# Altium schematic sheet [03] - POWER HIGH STAGE.SchDoc("[03] - POWER HIGH STAGE") (continued)

## Library data of the parts
C1 = Cap 0805 10nF/50V: 0805 10 nF 50 V ±5 % Tolerance X7R SMT Multilayer Ceramic Capacitor; Manufacturer Part Number: CC0805JRX7R9BB103; Manufacturer: Yageo; Voltage Rating (DC): 50V; Tolerance: 5%; Insulation Resistance: 10GR; Dissipation Factor: 2.5%; Capacitance: 10nF; Width: 0.049inch; Voltage Rating: 50V; Thickness: 0.071inch; Termination: SMD/SMT; Supplier Part Number 1: 311-1857-1-ND; Supplier 1: Digi-Key; Stock 1: 125618; Series: CC; RoHS Compliant: Yes; RoHS: Yes; Resistor Type: Ceramic; Radiation Hardening: No; Pricing 1: 1 = 0.14000, 10 = 0.09900, 50 = 0.05620, 100 = 0.04650, 500 = 0.03262, 1000 = 0.02700 (USD); Packaging: Tape and Reel; Package Quantity: 4000; Number of Pins: 2; Mount: Surface Mount; Min Operating Temperature: -55°C; Max Operating Temperature: 125°C; Length: 2mm; Lead Free: Lead Free; Height: 0.6mm; Halogen Free: Halogen Free; Dielectric: X7R; Depth: 1.25mm; Construction: Flat; ComponentLink4URL: https://datasheet.ciiva.com/13385/upy-gphc-x7r-6-3v-to-50v-8-13385902.pdf?src-supplier=Mouser; ComponentLink4Description: https://datasheet.ciiva.com/13385/upy-gphc-x7r-6-3v-to-50v-8-13385902.pdf?src-supplier=Mouser; ComponentLink3URL: https://datasheet.ciiva.com/11583/upy-gphc-x7r-6-3v-to-50v-8-11583532.pdf?src-supplier=Avnet; ComponentLink3Description: https://datasheet.ciiva.com/11583/upy-gphc-x7r-6-3v-to-50v-8-11583532.pdf?src-supplier=Avnet; ComponentLink2URL: https://datasheet.ciiva.com/17434/upy-gphc-x7r-6-3v-to-50v-8-17434678.pdf?src-supplier=Avnet+Europe; ComponentLink2Description: https://datasheet.ciiva.com/17434/upy-gphc-x7r-6-3v-to-50v-8-17434678.pdf?src-supplier=Avnet+Europe; ComponentLink1URL: https://datasheet.ciiva.com/26862/getdatasheetpartid-251801-26862952.pdf?src-supplier=Verical; ComponentLink1Description: https://datasheet.ciiva.com/26862/getdatasheetpartid-251801-26862952.pdf?src-supplier=Verical; Component Kind: Standard; Case Code (Metric): 2012; Case Code (Imperial): 0805; Case/Package: 0805; Library Name: Hieu_Lib.IntLib
C2 = Cap 0805 47nF/100V: Cap Ceramic 0.047uF 100V X7R 10% Pad SMD 0805 125C Automotive T/R; Manufacturer Part Number: CC0805KKX7R0BB473; Manufacturer: Yageo; Capacitance: 47nF; Width: 0.049inch; Weight: 0.000194oz; Voltage Rating (DC): 100V; Voltage Rating: 100V; Tolerance: 10%; Thickness: 0.057inch; Termination: SMD/SMT; Supplier Part Number 1: 311-1876-1-ND; Supplier 1: Digi-Key; Stock 1: 8993; Series: CC; RoHS Compliant: Yes; RoHS: Yes; Resistor Type: Ceramic; Radiation Hardening: No; Pricing 1: 1 = 0.19000, 10 = 0.13200, 50 = 0.07900, 100 = 0.06670, 500 = 0.04562, 1000 = 0.04036 (USD); Packaging: Tape and Reel; Mount: Surface Mount; Min Operating Temperature: -55°C; Max Operating Temperature: 125°C; Length: 2mm; Lead Free: Lead Free; Height: 1.25mm; Dielectric: X7R; Depth: 1.25mm; Construction: Flat; ComponentLink2URL: https://datasheet.ciiva.com/17434/upy-np0x7r-mv-100-to-630v-9-17434765.pdf?src-supplier=Avnet+Europe; ComponentLink2Description: https://datasheet.ciiva.com/17434/upy-np0x7r-mv-100-to-630v-9-17434765.pdf?src-supplier=Avnet+Europe; ComponentLink1URL: https://datasheet.ciiva.com/11583/upy-np0x7r-mv-100-to-630v-9-11583592.pdf?src-supplier=Avnet; ComponentLink1Description: https://datasheet.ciiva.com/11583/upy-np0x7r-mv-100-to-630v-9-11583592.pdf?src-supplier=Avnet; Component Kind: Standard; Case Code (Metric): 2012; Case Code (Imperial): 0805; Case/Package: 0805; Library Name: Hieu_Lib.IntLib
C3 C4 = Cap Tant 2917 22uF/35V: 22uF Solid MnO2 Tantalum Electrolytic Capacitor; 35 V dc +/-10%; T491 Series; Manufacturer Part Number: T491X226K035AT; Manufacturer: KEMET; Voltage Rating (DC): 35V; Tolerance: 10%; Polarity: Polar; Life (Hours): 2000Hour; Leakage Current: 7.7uA; ESR (Equivalent Series Resistance): 700mR; Dissipation Factor: 6%; Width: 4.3mm; Voltage Rating: 35V; Voltage: 35V; Termination: SMD/SMT; Supplier Part Number 1: 80-T491X226K035; Supplier 1: Mouser; Stock 1: 3885; Series: T491; RoHS Compliant: Yes; RoHS: Yes; REACH SVHC: No SVHC; Pricing 1: 1 = 2.02000, 10 = 1.39000, 50 = 1.39000, 100 = 1.20000, 1000 = 0.90300, 10000 = 0.90300 (USD); Packaging: Tape and Reel; Package Quantity: 1; Number of Pins: 2; Mount: Surface Mount,PCB; Min Operating Temperature: -55°C; Max Operating Temperature: 125°C; Length: 7.3mm; Lead Free: Lead Free; Height - Seated (Max): 0.169inch; Height: 4mm; Features: General Purpose; Dielectric Material: Tantalum; Depth: 4.3mm; Construction: Flat; ComponentLink8URL: https://datasheet.ciiva.com/14603/70095774-14603077.pdf?src-supplier=Allied+Electronics; ComponentLink8Description: https://datasheet.ciiva.com/14603/70095774-14603077.pdf?src-supplier=Allied+Electronics; ComponentLink7URL: https://datasheet.ciiva.com/12882/kem-t2005-t491-12882597.pdf?src-supplier=Mouser; ComponentLink7Description: https://datasheet.ciiva.com/12882/kem-t2005-t491-12882597.pdf?src-supplier=Mouser; ComponentLink6URL: https://datasheet.ciiva.com/260/kem-t2005-t491-260899.pdf?src-supplier=Digi-Key; ComponentLink6Description: https://datasheet.ciiva.com/260/kem-t2005-t491-260899.pdf?src-supplier=Digi-Key; ComponentLink5URL: https://datasheet.ciiva.com/7758/1446014-7758999.pdf?src-supplier=Element14; ComponentLink5Description: https://datasheet.ciiva.com/7758/1446014-7758999.pdf?src-supplier=Element14; ComponentLink4URL: https://datasheet.ciiva.com/7758/1446014-7758998.pdf?src-supplier=Element14; ComponentLink4Description: https://datasheet.ciiva.com/7758/1446014-7758998.pdf?src-supplier=Element14; ComponentLink3URL: https://datasheet.ciiva.com/260/kem-t2005-t491-260901.pdf?src-supplier=Digi-Key; ComponentLink3Description: https://datasheet.ciiva.com/260/kem-t2005-t491-260901.pdf?src-supplier=Digi-Key; ComponentLink2URL: https://datasheet.ciiva.com/260/kem-t2005-t491-260900.pdf?src-supplier=Digi-Key; ComponentLink2Description: https://datasheet.ciiva.com/260/kem-t2005-t491-260900.pdf?src-supplier=Digi-Key; ComponentLink1URL: https://datasheet.ciiva.com/11793/0900766b80718482-11793603.pdf?src-supplier=RSComponents; ComponentLink1Description: https://datasheet.ciiva.com/11793/0900766b80718482-11793603.pdf?src-supplier=RSComponents; Component Kind: Standard; Case Code (Metric): 7343; Case Code (Imperial): 2917; Case/Package: 2917; Capacitance: 22uF; Library Name: Hieu_Lib.IntLib
C5 C10 = Cap 0805 1uF/50V: 0805 1 uF 50 V ±10 % Tolerance X7R SMT Multilayer Ceramic Capacitor; Manufacturer Part Number: CC0805KKX7R9BB105; Manufacturer: Yageo; Voltage Rating (DC): 50V; Tolerance: 10%; Capacitance: 1uF; Width: 0.049inch; Weight: 0.000194oz; Voltage Rating: 50V; Thickness: 0.057inch; Termination: SMD/SMT; Supplier Part Number 1: 311-1886-1-ND; Supplier 1: Digi-Key; Stock 1: 174238; Series: CC; RoHS Compliant: Yes; RoHS: Yes; Resistor Type: Ceramic; Radiation Hardening: No; Pricing 1: 1 = 0.26000, 10 = 0.17900, 50 = 0.11920, 100 = 0.10130, 500 = 0.07152, 1000 = 0.06257 (USD); Packaging: Tape and Reel; Mount: Surface Mount; Min Operating Temperature: -55°C; Max Operating Temperature: 125°C; Length: 2mm; Lead Free: Lead Free; Height: 1.25mm; Halogen Free: Halogen Free; Dielectric: X7R; Depth: 1.25mm; Construction: Flat; ComponentLink1URL: https://datasheet.ciiva.com/13209/upy-gphc-x7r-6-3v-to-50v-8-12304-13209375.pdf?src-supplier=Mouser; ComponentLink1Description: https://datasheet.ciiva.com/13209/upy-gphc-x7r-6-3v-to-50v-8-12304-13209375.pdf?src-supplier=Mouser; Component Kind: Standard; Case Code (Metric): 2012; Case Code (Imperial): 0805; Case/Package: 0805; Library Name: Hieu_Lib.IntLib
C6 C9 C13 = Cap 0805 100nF/50V: Ceramic capacitor SMD-0805 100nF /50V X7R# K +-10%, Samsung, RoHS; Manufacturer Part Number: CL21B104KBCNNNC; Voltage Rating (DC): 50V; Tolerance: 10%; Dielectric: X7R; Width: 0.049inch; Voltage Rating: 50V; Voltage: 50V; Thickness: 0.037inch; Supplier Part Number 1: C1711; Supplier 1: LCSC; Stock 1: 447650; Series: CL; RoHS Compliant: Yes; RoHS: Yes; Radiation Hardening: No; Pricing 1: 50 = 0.04090, 500 = 0.03130, 1500 = 0.02890, 5000 = 0.02580, 25000 = 0.02430, 50000 = 0.02410, 50 = 0.03950, 500 = 0.03020, 1500 = 0.02790, 5000 = 0.02490, 25000 = 0.02350, 50000 = 0.02330, 50 = 0.20680, 500 = 0.15820, 1500 = 0.14600, 5000 = 0.13020, 25000 = 0.12290, 50000 = 0.12170, 50 = 0.19640, 500 = 0.15020, 1500 = 0.13860, 5000 = 0.12360, 25000 = 0.11670, 50000 = 0.11550, 50 = 0.02640, 500 = 0.02020, 1500 = 0.01860, 5000 = 0.01660, 25000 = 0.01570, 50000 = 0.01550, 50 = 0.02300, 500 = 0.01760, 1500 = 0.01620, 5000 = 0.01440, 25000 = 0.01360, 50000 = 0.01350, 50 = 0.24740, 500 = 0.18920, 1500 = 0.17470, 5000 = 0.15570, 25000 = 0.14700, 50000 = 0.14560, 50 = 3.45220, 500 = 2.64000, 1500 = 2.43700, 5000 = 2.17300, 25000 = 2.05110, 50000 = 2.03080, 50 = 0.13070, 500 = 0.09990, 1500 = 0.09220, 5000 = 0.08230, 25000 = 0.07760, 50000 = 0.07690, 50 = 2.39050, 500 = 1.82810, 1500 = 1.68750, 5000 = 1.50470, 25000 = 1.42030, 50000 = 1.40620, 50 = 0.26740, 500 = 0.20450, 1500 = 0.18870, 5000 = 0.16830, 25000 = 0.15890, 50000 = 0.15730, 50 = 0.04230, 500 = 0.03230, 1500 = 0.02990, 5000 = 0.02660, 25000 = 0.02510, 50000 = 0.02490, 50 = 0.03188, 500 = 0.02438, 1500 = 0.02251, 5000 = 0.02007, 25000 = 0.01894, 50000 = 0.01875 (AUD); Packaging: Tape and Reel; Mount: Surface Mount; Min Operating Temperature: -55°C; Max Operating Temperature: 125°C; Material: Ceramic; Manufacturer: Samsung; Length: 2mm; Lead Free: Lead Free; Height: 0.85mm; Depth: 1.25mm; Contact Plating: Tin; Construction: Flat; ComponentLink2URL: https://datasheet.ciiva.com/29199/454152-da-01-en-vs-kondensator-0805-29199814.pdf?src-supplier=Conrad; ComponentLink2Description: https://datasheet.ciiva.com/29199/454152-da-01-en-vs-kondensator-0805-29199814.pdf?src-supplier=Conrad; ComponentLink1URL: https://datasheet.ciiva.com/25710/cl21b104kbcnnnc-25710182.pdf?src-supplier=Digi-Key; ComponentLink1Description: https://datasheet.ciiva.com/25710/cl21b104kbcnnnc-25710182.pdf?src-supplier=Digi-Key; Component Kind: Standard; Case Code (Metric): 2012; Case Code (Imperial): 0805; Case/Package: 0805; Capacitance: 100nF; Library Name: Hieu_Lib.IntLib
C7 C8 = Cap Tant 2917 47uF/20V: Capacitor Tantalum Polymer 47uF 20% 20V 2-Pin Surface Mount T/R; Manufacturer Part Number: TCJY476M020R0070E; Manufacturer: Kyocera AVX; Supplier Part Number 1: 478-13360-1-ND; Supplier 1: Digi-Key; Stock 1: 4815; RoHS: Yes; Pricing 1: 1 = 1.37000, 10 = 1.08300, 50 = 0.93880, 100 = 0.81250, 500 = 0.61388 (USD); Component Kind: Standard; Library Name: Hieu_Lib.IntLib
C11 = Cap 0805 1nF/200V: Surface Mount Ceramic Multilayer Capacitor 0.001uF 10% X7R 200V 0805 Paper T/R; Manufacturer Part Number: CC0805KRX7RABB102; Manufacturer: Yageo; Capacitance: 1nF; Width: 0.049inch; Voltage Rating (DC): 200V; Voltage Rating: 200V; Tolerance: 10%; Thickness: 0.037inch; Termination: SMD/SMT; Supplier Part Number 1: 311-1469-1-ND; Supplier 1: Digi-Key; Stock 1: 74929; Series: CC; RoHS Compliant: Yes; RoHS: Yes; Resistor Type: Ceramic; REACH SVHC: No SVHC; Radiation Hardening: No; Pricing 1: 1 = 0.12000, 10 = 0.08400, 50 = 0.04780, 100 = 0.03970, 500 = 0.02782, 1000 = 0.02303 (USD); Packaging: Tape and Reel; Package Quantity: 4000; Number of Pins: 2; Mount: Surface Mount; Min Operating Temperature: -55°C; Max Operating Temperature: 125°C; Length: 2mm; Lead Free: Lead Free; Height: 0.85mm; Dielectric: X7R; Depth: 1.25mm; ComponentLink8URL: https://datasheet.ciiva.com/17436/upy-np0x7r-mv-100-to-630v-9-17436473.pdf?src-supplier=Avnet+Europe; ComponentLink8Description: https://datasheet.ciiva.com/17436/upy-np0x7r-mv-100-to-630v-9-17436473.pdf?src-supplier=Avnet+Europe; ComponentLink7URL: https://datasheet.ciiva.com/7978/1447671-7978217.pdf?src-supplier=Element14; ComponentLink7Description: https://datasheet.ciiva.com/7978/1447671-7978217.pdf?src-supplier=Element14; ComponentLink6URL: https://datasheet.ciiva.com/11465/upy-np0x7r-mv-100-to-630v-9-11465767.pdf?src-supplier=Avnet; ComponentLink6Description: https://datasheet.ciiva.com/11465/upy-np0x7r-mv-100-to-630v-9-11465767.pdf?src-supplier=Avnet; ComponentLink5URL: https://datasheet.ciiva.com/177/mlccorderingcode-177538.pdf?src-supplier=Digi-Key; ComponentLink5Description: https://datasheet.ciiva.com/177/mlccorderingcode-177538.pdf?src-supplier=Digi-Key; ComponentLink4URL: https://datasheet.ciiva.com/13210/yageo-486313-494411-13210767.pdf?src-supplier=Mouser; ComponentLink4Description: https://datasheet.ciiva.com/13210/yageo-486313-494411-13210767.pdf?src-supplier=Mouser; ComponentLink3URL: https://datasheet.ciiva.com/177/mlccorderingcode-177540.pdf?src-supplier=Digi-Key; ComponentLink3Description: https://datasheet.ciiva.com/177/mlccorderingcode-177540.pdf?src-supplier=Digi-Key; ComponentLink2URL: https://datasheet.ciiva.com/177/mlccorderingcode-177539.pdf?src-supplier=Digi-Key; ComponentLink2Description: https://datasheet.ciiva.com/177/mlccorderingcode-177539.pdf?src-supplier=Digi-Key; ComponentLink1URL: https://datasheet.ciiva.com/26862/getdatasheetpartid-403717-26862996.pdf?src-supplier=Verical; ComponentLink1Description: https://datasheet.ciiva.com/26862/getdatasheetpartid-403717-26862996.pdf?src-supplier=Verical; Component Kind: Standard; Case Code (Metric): 2012; Case Code (Imperial): 0805; Case/Package: 0805; Library Name: Hieu_Lib.IntLib
C12 = Cap Aluminum 68uF/100V: Cap Aluminum Lytic 68uF 100V 20% (10 X 16mm) Radial 5mm 930mA 8000h 105C Bulk; Manufacturer Part Number: EEUFS2A680; Manufacturer: Panasonic; Supplier Part Number 1: 667-EEU-FS2A680; Supplier 1: Mouser; Stock 1: 1647; RoHS Compliant: Yes; RoHS: Yes; Pricing 1: 1 = 0.91000, 10 = 0.67400, 50 = 0.67400, 100 = 0.46300, 600 = 0.36600, 1000 = 0.30800, 10000 = 0.26900 (USD); Component Kind: Standard; Library Name: Hieu_Lib.IntLib
C14 C19 = Cap Disc 1nF/2kV: ±10% 1nF 3mm Y5P 8mm 5mm 2kV Radial Leaded,P=5mm Ceramic Disc Capacitors ROHS; Manufacturer Part Number: R08B1B102KQ0T0S0N0; Manufacturer: STE(Songtian Elec); Supplier Part Number 1: C366824; Supplier 1: LCSC; Component Kind: Standard; Library Name: Hieu_Lib.IntLib
C15 = Cap Aluminum 680uF/50V 1840mA: Cap Aluminum Lytic 680uF 50V 20%( 16 X 20mm) Radial 7.5mm 1840mA 8000h 105C Automotive Bulk; Manufacturer Part Number: ELXZ500ELL681ML20S; Manufacturer: United Chemi-Con; Ripple Current: 1.84A; Life (Hours): 8000Hour; Leakage Current: 3uA; Lead Diameter: 0.8mm; Impedance: 48mR; ESR (Equivalent Series Resistance): 96mR; Voltage Rating (DC): 50V; Voltage Rating: 50V; Voltage: 50V; Tolerance: 20%; Termination: Radial; Supplier Part Number 1: 661-ELXZ500ELL681ML2; Supplier 1: Mouser; Stock 1: 496; Series: LXZ; RoHS Compliant: Yes; RoHS: Yes; Pricing 1: 1 = 2.03000, 10 = 1.65000, 50 = 1.65000, 100 = 1.26000, 1000 = 0.83800, 10000 = 0.76300 (USD); Packaging: Bulk; Package Quantity: 500; Number of Pins: 20; Mount: Through Hole; Min Operating Temperature: -55°C; Max Operating Temperature: 105°C; Length: 20mm; Lead Pitch: 0.295inch; Lead Free: Lead Free; Height - Seated (Max): 0.787inch; Height: 20mm; Diameter: 16mm; Construction: RADIAL; ComponentLink1URL: https://datasheet.ciiva.com/25613/0900766b81084d89-25613840.pdf?src-supplier=RSComponents; ComponentLink1Description: https://datasheet.ciiva.com/25613/0900766b81084d89-25613840.pdf?src-supplier=RSComponents; Component Kind: Standard; Case/Package: Radial; Capacitance: 680uF; Library Name: Hieu_Lib.IntLib
C16 = Cap Aluminum 330uF/50V: Cap Aluminum 330uF 50V 20% (12.5 X 20mm) Radial 5mm 0.383 Ohm 1820mA 7000 hr 125°C Bulk; Manufacturer Part Number: B41896C6337M000; Manufacturer: TDK EPCOS; Ripple Current: 1.82A; Life (Hours): 7000Hour; Lead Diameter: 0.6mm; ESR (Equivalent Series Resistance): 383mR; Tolerance: 20%; Supplier Part Number 1: 871-B41896C6337M000; Supplier 1: Mouser; Stock 1: 7307; RoHS Compliant: Yes; RoHS: Yes; Pricing 1: 1 = 1.68000, 10 = 1.16000, 50 = 1.16000, 100 = 1.00000, 1000 = 0.75500, 10000 = 0.59900 (USD); Packaging: Bulk; Min Operating Temperature: -55°C; Max Operating Temperature: 125°C; Lead Pitch: 5mm; ComponentLink2URL: https://www.tdk-electronics.tdk.com/inf/20/30/db/aec/B41896.pdf?src-supplier=Digi-Key; ComponentLink2Description: https://www.tdk-electronics.tdk.com/inf/20/30/db/aec/B41896.pdf?src-supplier=Digi-Key; ComponentLink1URL: http://components.arrow.com/part/dataview/0323_01840345?src-supplier=Arrow+Europe; ComponentLink1Description: http://components.arrow.com/part/dataview/0323_01840345?src-supplier=Arrow+Europe; Component Kind: Standard; Case/Package: Radial; Capacitance: 330uF; Library Name: Hieu_Lib.IntLib
C17 = Cap Aluminum 220uF/25V: Electrolytic Capacitor, Wcap-Atll Series, 220 F, 20%, 25 V, 8 Mm, Radial Leaded Rohs Compliant: Yes; Manufacturer Part Number: 860160474017; Manufacturer: Wurth Electronics; Voltage Rating (DC): 25V; Material: Aluminium; Life (Hours): 7000Hour; Capacitance: 220uF; Voltage Rating: 25V; Tolerance: 20%; Supplier Part Number 1: 732-9517-1-ND; Supplier 1: Digi-Key; Stock 1: 110; RoHS Compliant: Yes; RoHS: Yes; REACH SVHC: Unknown; Pricing 1: 1 = 0.33000, 50 = 0.27800, 100 = 0.24200, 250 = 0.21500 (USD); Min Operating Temperature: -55°C; Max Operating Temperature: 105°C; Lead Pitch: 3.5mm; Height: 11.5mm; Diameter: 8mm; ComponentLink4URL: http://www.farnell.com/datasheets/1958410.pdf?src-supplier=Element14; ComponentLink4Description: http://www.farnell.com/datasheets/1958410.pdf?src-supplier=Element14; ComponentLink3URL: https://katalog.we-online.de/pbs/datasheet/860160474017.pdf?src-supplier=Digi-Key; ComponentLink3Description: https://katalog.we-online.de/pbs/datasheet/860160474017.pdf?src-supplier=Digi-Key; ComponentLink2URL: http://www.produktinfo.conrad.com/datenblaetter/1200000-1299999/001281591-da-01-en-WCAP_ATLL_ALU_ELEC_CAPS_860160474017.pdf?src-supplier=Conrad; ComponentLink2Description: http://www.produktinfo.conrad.com/datenblaetter/1200000-1299999/001281591-da-01-en-WCAP_ATLL_ALU_ELEC_CAPS_860160474017.pdf?src-supplier=Conrad; ComponentLink1URL: http://www.farnell.com/datasheets/1958410.pdf?src-supplier=Farnell; ComponentLink1Description: http://www.farnell.com/datasheets/1958410.pdf?src-supplier=Farnell; Component Kind: Standard; Case/Package: Radial; Library Name: Hieu_Lib.IntLib
C18 = Cap 1206 4.7uF/50V: Cap Ceramic 4.7uF 50V X7R 10% Pad SMD 1206 125C T/R; Manufacturer Part Number: CC1206KKX7R9BB475; Manufacturer: Yageo; Width: 0.063inch; Voltage Rating: 50V; Tolerance: 10%; Thickness: 0.071inch; Supplier Part Number 1: 603-CC206KKX7R9BB475; Supplier 1: Mouser; Stock 1: 11897; RoHS Compliant: Yes; RoHS: Yes; Pricing 1: 1 = 0.54000, 10 = 0.30800, 50 = 0.30800, 100 = 0.22500, 500 = 0.16900, 1000 = 0.14400, 2000 = 0.13500, 10000 = 0.13500, 24000 = 0.11800 (USD); Packaging: Tape and Reel; Mount: Surface Mount; Min Operating Temperature: -55°C; Max Operating Temperature: 125°C; Length: 0.126inch; Lead Free: Lead Free; Halogen Free: Halogen Free; ComponentLink3URL: http://www.yageo.com/documents/recent/UPY-GPHC_X7R_6.3V-to-50V_18.pdf?src-supplier=Digi-Key; ComponentLink3Description: http://www.yageo.com/documents/recent/UPY-GPHC_X7R_6.3V-to-50V_18.pdf?src-supplier=Digi-Key; ComponentLink2URL: http://components.arrow.com/part/dataview/0323_07044541?src-supplier=Arrow+Europe; ComponentLink2Description: http://components.arrow.com/part/dataview/0323_07044541?src-supplier=Arrow+Europe; ComponentLink1URL: http://www.mouser.com/ds/2/447/Yageo_UPY-GP_NP0_16V-to-50V_9-358835.pdf?src-supplier=Mouser; ComponentLink1Description: http://www.mouser.com/ds/2/447/Yageo_UPY-GP_NP0_16V-to-50V_9-358835.pdf?src-supplier=Mouser; Component Kind: Standard; Case Code (Metric): 3216; Case Code (Imperial): 1206; Case/Package: 1206; Capacitance: 4.7uF; Library Name: Hieu_Lib.IntLib
D1 D2 = Zenner 12V 500mW: 100nA 12V 500mW LL-34 Zener Diodes ROHS; Manufacturer Part Number: ZMM12; Manufacturer: Slkor; Supplier Part Number 1: C707203; Supplier 1: LCSC; Stock 1: 37550; RoHS: No; Pricing 1: 50 = 0.11440, 500 = 0.08750, 1500 = 0.08250, 5000 = 0.07760, 25000 = 0.07540, 50000 = 0.07430, 50 = 0.11050, 500 = 0.08450, 1500 = 0.07970, 5000 = 0.07490, 25000 = 0.07280, 50000 = 0.07180, 50 = 0.57820, 500 = 0.44200, 1500 = 0.41700, 5000 = 0.39200, 25000 = 0.38090, 50000 = 0.37540, 50 = 0.54900, 500 = 0.41970, 1500 = 0.39590, 5000 = 0.37220, 25000 = 0.36160, 50000 = 0.35640, 50 = 0.07380, 500 = 0.05640, 1500 = 0.05330, 5000 = 0.05010, 25000 = 0.04860, 50000 = 0.04790, 50 = 0.06420, 500 = 0.04910, 1500 = 0.04630, 5000 = 0.04350, 25000 = 0.04230, 50000 = 0.04170, 50 = 0.69180, 500 = 0.52890, 1500 = 0.49900, 5000 = 0.46900, 25000 = 0.45570, 50000 = 0.44920, 50 = 9.65190, 500 = 7.37890, 1500 = 6.96130, 5000 = 6.54390, 25000 = 6.35830, 50000 = 6.26660, 50 = 0.36540, 500 = 0.27930, 1500 = 0.26350, 5000 = 0.24770, 25000 = 0.24070, 50000 = 0.23720, 50 = 6.68350, 500 = 5.10950, 1500 = 4.82040, 5000 = 4.53130, 25000 = 4.40280, 50000 = 4.33930, 50 = 0.74760, 500 = 0.57150, 1500 = 0.53920, 5000 = 0.50680, 25000 = 0.49250, 50000 = 0.48540, 50 = 0.11820, 500 = 0.09040, 1500 = 0.08530, 5000 = 0.08020, 25000 = 0.07790, 50000 = 0.07680, 50 = 0.08913, 500 = 0.06814, 1500 = 0.06429, 5000 = 0.06043, 25000 = 0.05872, 50000 = 0.05787 (AUD); Component Kind: Standard; Library Name: Hieu_Lib.SchLib
D3 = SS34: SS Series 500 mV 3 A 40 V Max Reverse Voltage Schottky Rectifier - DO-214AB; Manufacturer: ON Semiconductor / Fairchild; Voltage Rating (DC): 40V; Polarity: Standard; Peak Non-Repetitive Surge Current: 100A; Output Current: 3A; Number of Terminals/Pins: 2; Number of Elements: 1; Max Reverse Leakage Current: 500uA; Max Power Dissipation: 2.27W; Forward Voltage: 500mV; Element Configuration: Single; Width: 6.25mm; Voltage: 40V; Supplier Part Number 1: SS34; Supplier 1: Avnet; Stock 1: 325851; RoHS Compliant: Yes; RoHS: Yes; REACH SVHC: No SVHC; Radiation Hardening: No; Pricing 1: 1447 = 0.28183, 1500 = 0.27942, 2900 = 0.27751, 7300 = 0.27638, 15000 = 0.27554, 73000 = 0.27470, 150000 = 0.27386, 3000 = 0.27890, 6000 = 0.27650, 12000 = 0.27462, 18000 = 0.27638, 24000 = 0.27554, 30000 = 0.27470, 300000 = 0.27386 (USD); Packaging: Tape and Reel; Package Quantity: 1; Number of Pins: 2; Mount: Surface Mount; Min Operating Temperature: -55°C; Max Surge Current: 100A; Max Reverse Voltage (DC): 40V; Max Reverse Current: 500uA; Max Repetitive Reverse Voltage (Vrrm): 40V; Max Operating Temperature: 150°C; Max Forward Surge Current (Ifsm): 100A; Manufacturer Part Number: SS34; Length: 7.15mm; Lead Free: Lead Free; Height: 2.75mm; Forward Current: 3A; Current Rating: 3A; Current: 3A; Contact Plating: Tin,Matte; Component Kind: Standard; Case/Package: SMC; Average Rectified Current: 3A; Library Name: Hieu_Lib.IntLib
D4 = Zenner 5.1V 500mW: 100nA@5.1V 500mW LL-34 Zener Diodes ROHS; Manufacturer Part Number: ZMM5V1; Manufacturer: Slkor; Supplier Part Number 1: C707194; Supplier 1: LCSC; Stock 1: 89450; RoHS: No; Pricing 1: 50 = 0.11440, 500 = 0.08750, 1500 = 0.08250, 5000 = 0.07760, 25000 = 0.07540, 50000 = 0.07430, 50 = 0.11050, 500 = 0.08450, 1500 = 0.07970, 5000 = 0.07490, 25000 = 0.07280, 50000 = 0.07180, 50 = 0.57820, 500 = 0.44200, 1500 = 0.41700, 5000 = 0.39200, 25000 = 0.38090, 50000 = 0.37540, 50 = 0.54900, 500 = 0.41970, 1500 = 0.39590, 5000 = 0.37220, 25000 = 0.36160, 50000 = 0.35640, 50 = 0.07380, 500 = 0.05640, 1500 = 0.05330, 5000 = 0.05010, 25000 = 0.04860, 50000 = 0.04790, 50 = 0.06420, 500 = 0.04910, 1500 = 0.04630, 5000 = 0.04350, 25000 = 0.04230, 50000 = 0.04170, 50 = 0.69180, 500 = 0.52890, 1500 = 0.49900, 5000 = 0.46900, 25000 = 0.45570, 50000 = 0.44920, 50 = 9.65190, 500 = 7.37890, 1500 = 6.96130, 5000 = 6.54390, 25000 = 6.35830, 50000 = 6.26660, 50 = 0.36540, 500 = 0.27930, 1500 = 0.26350, 5000 = 0.24770, 25000 = 0.24070, 50000 = 0.23720, 50 = 6.68350, 500 = 5.10950, 1500 = 4.82040, 5000 = 4.53130, 25000 = 4.40280, 50000 = 4.33930, 50 = 0.74760, 500 = 0.57150, 1500 = 0.53920, 5000 = 0.50680, 25000 = 0.49250, 50000 = 0.48540, 50 = 0.11820, 500 = 0.09040, 1500 = 0.08530, 5000 = 0.08020, 25000 = 0.07790, 50000 = 0.07680, 50 = 0.08913, 500 = 0.06814, 1500 = 0.06429, 5000 = 0.06043, 25000 = 0.05872, 50000 = 0.05787 (AUD); Component Kind: Standard; Library Name: Hieu_Lib.IntLib
D5 D6 = TVS SMCJ26CA: Diode TVS Single Bi-Dir 26V 1.5KW 2-Pin DO-214AB; Manufacturer: Bourns; Test Current: 1mA; Reverse Breakdown Voltage: 28.9V; Power Line Protection: No; Polarity: Bidirectional; Peak Pulse Current: 35.6A; Operating Supply Voltage: 3.5V; Number of Elements: 1; Max Surge Current: 200A; Max Reverse Leakage Current: 5uA; Max Power Dissipation: 5W; Max Breakdown Voltage: 32.2V; Diode Type: ZENER; Clamping Voltage: 42.1V; Termination: SMD/SMT; Supplier Part Number 1: SMCJ26CABCT-ND; Supplier 1: Digi-Key; Stock 1: 0; Series: SMCJ; RoHS Compliant: Yes; RoHS: Yes; Reverse Standoff Voltage: 26V; Resistor Type: Zener; REACH SVHC: No SVHC; Peak Pulse Power: 1.5kW; Packaging: Tape and Reel; Package Quantity: 1; Number of Pins: 2; Number of Channels: 1; Number of Bidirectional Channels: 1; Mount: Surface Mount; Min Operating Temperature: -55°C; Min Breakdown Voltage: 28.9V; Max Operating Temperature: 150°C; Leakage Current: 5uA; Element Configuration: Single; Direction: Bidirectional; ComponentLink6URL: https://datasheet.ciiva.com/336/smcj-336301.pdf?src-supplier=Digi-Key; ComponentLink6Description: https://datasheet.ciiva.com/336/smcj-336301.pdf?src-supplier=Digi-Key; ComponentLink5URL: https://datasheet.ciiva.com/336/smcj-336299.pdf?src-supplier=Digi-Key; ComponentLink5Description: https://datasheet.ciiva.com/336/smcj-336299.pdf?src-supplier=Digi-Key; ComponentLink4URL: https://datasheet.ciiva.com/8031/1695389-8031190.pdf?src-supplier=Element14; ComponentLink4Description: https://datasheet.ciiva.com/8031/1695389-8031190.pdf?src-supplier=Element14; ComponentLink3URL: https://datasheet.ciiva.com/16237/smcj-16237548.pdf?src-supplier=Onlinecomponents.com; ComponentLink3Description: https://datasheet.ciiva.com/16237/smcj-16237548.pdf?src-supplier=Onlinecomponents.com; ComponentLink2URL: https://datasheet.ciiva.com/336/smcj-336300.pdf?src-supplier=Digi-Key; ComponentLink2Description: https://datasheet.ciiva.com/336/smcj-336300.pdf?src-supplier=Digi-Key; ComponentLink1URL: https://datasheet.ciiva.com/26787/smcj26ca-26787354.pdf?src-supplier=IIIC; ComponentLink1Description: https://datasheet.ciiva.com/26787/smcj26ca-26787354.pdf?src-supplier=IIIC; Component Kind: Standard; Case/Package: DO-214AB; Breakdown Voltage: 32.2V; Library Name: Hieu_Lib.IntLib
L1 = Inductor 68uH 1A: Inductor Power Shielded Wirewound 68uH 20% 100KHz Ferrite 1A 0.403Ohm DCR 2424 T/R; Manufacturer Part Number: VLS6045EX-680M; Manufacturer: TDK; DC Resistance (DCR): 310mR; Width: 0.236inch; Tolerance: 20%; Test Frequency: 100kHz; Supplier Part Number 1: 810-VLS6045EX-680M; Supplier 1: Mouser; Stock 1: 5378; Shielding: Shielded; RoHS Compliant: Yes; RoHS: Yes; Resistor Type: Wirewound; Pricing 1: 1 = 0.51000, 10 = 0.34800, 50 = 0.34800, 100 = 0.31200, 1000 = 0.25800, 10000 = 0.19000 (USD); Packaging: Cut Tape; Mount: Surface Mount; Min Operating Temperature: -40°C; Max Operating Temperature: 105°C; Length: 0.236inch; Lead Free: Lead Free; Inductance: 68uH; Height - Seated (Max): 0.177inch; Current Rating: 1A; Core Material: Ferrite; ComponentLink2URL: https://product.tdk.com/info/en/catalog/datasheets/inductor_commercial_power_vls6045ex_en.pdf?src-supplier=Digi-Key; ComponentLink2Description: https://product.tdk.com/info/en/catalog/datasheets/inductor_commercial_power_vls6045ex_en.pdf?src-supplier=Digi-Key; ComponentLink1URL: https://media.digikey.com/pdf/Data%20Sheets/TDK%20PDFs/VLS-EX_Series_Br.pdf?src-supplier=Digi-Key; ComponentLink1Description: https://media.digikey.com/pdf/Data%20Sheets/TDK%20PDFs/VLS-EX_Series_Br.pdf?src-supplier=Digi-Key; Component Kind: Standard; Case/Package: Nonstandard; Library Name: Hieu_Lib.IntLib
L2 = Common Mode Filter FL2D: 40mΩ 1mH @ 1kHz SignalLine DIP Common Mode Filters ROHS; Manufacturer Part Number: FL2D-30-102; Manufacturer: MORNSUN; Supplier Part Number 1: C194629; Supplier 1: LCSC; Component Kind: Standard; Library Name: Hieu_Lib.IntLib
R1 R2 = Res 0805 10 Ohm 1%: Res SMD 0805 10R 1% 0.125W T.C.100PPM; Manufacturer Part Number: RC0805FR-0710RL; Manufacturer: Yageo; Voltage Rating (DC): 150V; Temperature Coefficient: 200ppm/°C; Power Rating: 125mW; Width: 1.25mm; Voltage Rating: 150V; Tolerance: 1%; Termination: SMD/SMT; Technology: THICK FILM; Supplier Part Number 1: 311-10.0CRCT-ND; Supplier 1: Digi-Key; Stock 1: 0; Size Code: 0805; Series: RC; RoHS Compliant: Yes; RoHS: Yes; Resistor Type: Thick Film; Resistance Tolerance: 1%; Resistance: 10R; REACH SVHC: No SVHC; Packaging: Tape and Reel; Package Quantity: 1; Number of Terminations: 2; Number of Pins: 2; Min Operating Temperature: -55°C; Max Power Dissipation: 125mW; Max Operating Temperature: 155°C; Length: 2mm; Lead Free: Lead Free; Height: 0.5mm; Features: Moisture Resistant; Depth: 1.25mm; Construction: Rectangular; ComponentLink9URL: https://datasheet.ciiva.com/2457/pyu-r-int-thick-7-2457099.pdf?src-supplier=Digi-Key; ComponentLink9Description: https://datasheet.ciiva.com/2457/pyu-r-int-thick-7-2457099.pdf?src-supplier=Digi-Key; ComponentLink8URL: https://datasheet.ciiva.com/2457/pyu-r-int-thick-7-2457098.pdf?src-supplier=Digi-Key; ComponentLink8Description: https://datasheet.ciiva.com/2457/pyu-r-int-thick-7-2457098.pdf?src-supplier=Digi-Key; ComponentLink7URL: https://datasheet.ciiva.com/12555/rc0805-257173-12555112.pdf?src-supplier=Mouser; ComponentLink7Description: https://datasheet.ciiva.com/12555/rc0805-257173-12555112.pdf?src-supplier=Mouser; ComponentLink6URL: https://datasheet.ciiva.com/46447/444164-46447565.pdf?src-supplier=Element14; ComponentLink6Description: https://datasheet.ciiva.com/46447/444164-46447565.pdf?src-supplier=Element14; ComponentLink5URL: https://datasheet.ciiva.com/2457/pyu-r-int-thick-7-2457100.pdf?src-supplier=Digi-Key; ComponentLink5Description: https://datasheet.ciiva.com/2457/pyu-r-int-thick-7-2457100.pdf?src-supplier=Digi-Key; ComponentLink4URL: https://datasheet.ciiva.com/46412/444164-46412859.pdf?src-supplier=Element14; ComponentLink4Description: https://datasheet.ciiva.com/46412/444164-46412859.pdf?src-supplier=Element14; ComponentLink3URL: https://datasheet.ciiva.com/7941/444164-7941688.pdf?src-supplier=Element14; ComponentLink3Description: https://datasheet.ciiva.com/7941/444164-7941688.pdf?src-supplier=Element14; ComponentLink2URL: https://datasheet.ciiva.com/7941/444164-7941687.pdf?src-supplier=Element14; ComponentLink2Description: https://datasheet.ciiva.com/7941/444164-7941687.pdf?src-supplier=Element14; ComponentLink1URL: https://datasheet.ciiva.com/11809/0900766b8106beab-11809370.pdf?src-supplier=RSComponents; ComponentLink1Description: https://datasheet.ciiva.com/11809/0900766b8106beab-11809370.pdf?src-supplier=RSComponents; Component Kind: Standard; Case Code (Metric): 2012; Case Code (Imperial): 0805; Case/Package: 0805; Library Name: Hieu_Lib.IntLib
R3 = Res 0805 3.48k Ohm 1%: Chip Resistor Automotive Grade Thick Film 0805 3.48kOhm 1% Paper T/R; Manufacturer Part Number: AC0805FR-073K48L; Manufacturer: Yageo; Temperature Coefficient: 100ppm/°C; Resistance: 3.48kR; Max Power Dissipation: 125mW; Width: 0.049inch; Tolerance: 1%; Supplier Part Number 1: 603-AC0805FR-073K48L; Supplier 1: Mouser; Stock 1: 12976; RoHS Compliant: Yes; RoHS: Yes; Resistor Type: Thick Film; Pricing 1: 1 = 0.16000, 10 = 0.05200, 50 = 0.05200, 100 = 0.02200, 1000 = 0.01000, 10000 = 0.00600 (USD); Packaging: Tape and Reel; Number of Terminations: 2; Min Operating Temperature: -55°C; Max Operating Temperature: 155°C; Length: 0.079inch; Height: 0.024inch; Features: Moisture Resistant,Automotive AEC-Q200; ComponentLink1URL: http://www.yageo.com/NewPortal/yageodocoutput?fileName=/pdf/R-Chip/PYu-AC_51_RoHS_L_6.pdf&src-supplier=Digi-Key; ComponentLink1Description: http://www.yageo.com/NewPortal/yageodocoutput?fileName=/pdf/R-Chip/PYu-AC_51_RoHS_L_6.pdf&src-supplier=Digi-Key; Component Kind: Standard; Case/Package: 0805; Library Name: Hieu_Lib.IntLib
R4 = Res 0805 91k Ohm 1%: Res Thick Film 0805 91K Ohm 1% 0.125W(1/8W) ±100ppm/C Pad SMD T/R; Manufacturer Part Number: RC0805FR-0791KL; Manufacturer: Yageo; Voltage Rating (DC): 150V; Temperature Coefficient: 100ppm/°C; Resistance: 91kR; Power Rating: 125mW; Width: 0.049inch; Voltage Rating: 150V; Tolerance: 1%; Termination: SMD/SMT; Technology: THICK FILM; Supplier Part Number 1: 311-91.0KCRCT-ND; Supplier 1: Digi-Key; Stock 1: 100242; Size Code: 0805; Series: RC; RoHS Compliant: Yes; RoHS: Yes; Resistor Type: Thick Film; Pricing 1: 1 = 0.10000, 10 = 0.04200, 100 = 0.01690, 1000 = 0.00759, 2500 = 0.00659 (USD); Packaging: Tape and Reel; Package Quantity: 1; Number of Terminations: 2; Mount: Surface Mount; Min Operating Temperature: -55°C; Military Standard: Not; Max Power Dissipation: 125mW; Max Operating Temperature: 155°C; Length: 2mm; Lead Free: Lead Free; Height: 0.024inch; Features: Moisture Resistant; Depth: 1.25mm; Construction: Rectangular; ComponentLink6URL: https://datasheet.ciiva.com/2457/pyu-r-int-thick-7-2457647.pdf?src-supplier=Digi-Key; ComponentLink6Description: https://datasheet.ciiva.com/2457/pyu-r-int-thick-7-2457647.pdf?src-supplier=Digi-Key; ComponentLink5URL: https://datasheet.ciiva.com/17422/rc0805-17422988.pdf?src-supplier=Future+Electronics; ComponentLink5Description: https://datasheet.ciiva.com/17422/rc0805-17422988.pdf?src-supplier=Future+Electronics; ComponentLink4URL: https://datasheet.ciiva.com/12667/rc0805-12667335.pdf?src-supplier=Mouser; ComponentLink4Description: https://datasheet.ciiva.com/12667/rc0805-12667335.pdf?src-supplier=Mouser; ComponentLink3URL: https://datasheet.ciiva.com/2457/pyu-r-int-thick-7-2457649.pdf?src-supplier=Digi-Key; ComponentLink3Description: https://datasheet.ciiva.com/2457/pyu-r-int-thick-7-2457649.pdf?src-supplier=Digi-Key; ComponentLink2URL: https://datasheet.ciiva.com/2457/pyu-r-int-thick-7-2457648.pdf?src-supplier=Digi-Key; ComponentLink2Description: https://datasheet.ciiva.com/2457/pyu-r-int-thick-7-2457648.pdf?src-supplier=Digi-Key; ComponentLink1URL: https://datasheet.ciiva.com/11809/0900766b8106beab-11809452.pdf?src-supplier=RSComponents; ComponentLink1Description: https://datasheet.ciiva.com/11809/0900766b8106beab-11809452.pdf?src-supplier=RSComponents; Component Kind: Standard; Case Code (Metric): 2012; Case Code (Imperial): 0805; Case/Package: 0805; Library Name: Hieu_Lib.IntLib
R5 = KRL1632E-M-R015-F-T5: KRL Series 1206 0.75 W 0.015 Ohm ±1 % ±50 ppm/°C SMT Metal Foil Chip Resistor; Manufacturer: Susumu; Tolerance: 1%; Resistance: 15mR; Power Rating: 750mW; Width: 1.6mm; Weight: 0.000466oz; Termination: SMD/SMT; Temperature Coefficient: 50ppm/°C; Supplier Part Number 1: 408-1572-6-ND; Supplier 1: Digi-Key; Stock 1: 42498; RoHS: No; Resistor Type: Metal Foil; Pricing 1: 1 = 0.49000, 10 = 0.35000, 25 = 0.27280, 50 = 0.23100, 100 = 0.18200, 250 = 0.15400, 500 = 0.12600, 1000 = 0.09800 (USD); Packaging: Tape and Reel; Min Operating Temperature: -55°C; Max Operating Temperature: 155°C; Manufacturer Part Number: KRL1632E-M-R015-F-T5; Length: 3.2mm; Lead Free: Lead Free; Height: 0.5mm; ComponentLink1URL: https://datasheet.ciiva.com/12837/products-21-12837655.pdf?src-supplier=Mouser; ComponentLink1Description: https://datasheet.ciiva.com/12837/products-21-12837655.pdf?src-supplier=Mouser; Component Kind: Standard; Case Code (Metric): 1632; Case Code (Imperial): 0612; Case/Package: 0612; Library Name: Hieu_Lib.IntLib
R6 = Res 0805 1k Ohm 1%: Res Thick Film 0805 1KOhm 1% 0.125W(1/8W) 100ppm/C Epoxy Pad SMD T/R; Manufacturer Part Number: RC0805FR-071KL; Manufacturer: Yageo; Voltage Rating (DC): 150V; Temperature Coefficient: 100ppm/°C; Resistance: 1kR; Power Rating: 125mW; Width: 0.049inch; Voltage Rating: 150V; Tolerance: 1%; Termination: SMD/SMT; Supplier Part Number 1: 311-1.00KCRCT-ND; Supplier 1: Digi-Key; Stock 1: 2324983; Size Code: 0805; Series: RC; RoHS Compliant: Yes; RoHS: Yes; Resistor Type: Thick Film; Resistance Tolerance: 1%; REACH SVHC: Unknown; Pricing 1: 1 = 0.10000, 10 = 0.04200, 100 = 0.01690, 1000 = 0.00759, 2500 = 0.00659 (USD); Packaging: Tape and Reel; Package Quantity: 1; Number of Terminations: 2; Number of Pins: 2; Min Operating Temperature: -55°C; Military Standard: Not; Max Power Dissipation: 125mW; Max Operating Temperature: 155°C; Length: 0.079inch; Lead Free: Lead Free; Height: 0.024inch; Features: Moisture Resistant; Depth: 1.25mm; Construction: Rectangular; Component Kind: Standard; Case Code (Metric): 2012; Case Code (Imperial): 0805; Case/Package: 0805; Library Name: Hieu_Lib.IntLib
R7 = Res 0805 20k Ohm 1%: Res Thick Film 0805 20K Ohm 1% 0.125W(1/8W) ±100ppm/C Pad SMD T/R; Manufacturer Part Number: RC0805FR-0720KL; Manufacturer: Yageo; Voltage Rating (DC): 150V; Temperature Coefficient: 100ppm/°C; Resistance: 20kR; Power Rating: 125mW; Voltage Rating: 150V; Tolerance: 1%; Termination: SMD/SMT; Supplier Part Number 1: 311-20.0KCRCT-ND; Supplier 1: Digi-Key; Stock 1: 602044; Size Code: 0805; Series: RC; RoHS Compliant: Yes; RoHS: Yes; Resistor Type: Thick Film; Resistance Tolerance: 1%; REACH SVHC: Unknown; Pricing 1: 1 = 0.10000, 10 = 0.04200, 100 = 0.01690, 1000 = 0.00759, 2500 = 0.00659 (USD); Packaging: Tape and Reel; Number of Terminations: 2; Number of Pins: 2; Min Operating Temperature: -55°C; Military Standard: Not; Max Power Dissipation: 125mW; Max Operating Temperature: 155°C; Length: 2mm; Lead Free: Lead Free; Height: 0.5mm; Features: Moisture Resistant; Depth: 1.25mm; Construction: Rectangular; ComponentLink3URL: https://datasheet.ciiva.com/12666/rc0805-12666938.pdf?src-supplier=Mouser; ComponentLink3Description: https://datasheet.ciiva.com/12666/rc0805-12666938.pdf?src-supplier=Mouser; ComponentLink2URL: https://datasheet.ciiva.com/46395/444164-46395127.pdf?src-supplier=Element14; ComponentLink2Description: https://datasheet.ciiva.com/46395/444164-46395127.pdf?src-supplier=Element14; ComponentLink1URL: https://datasheet.ciiva.com/15189/getdatasheetpartid-330251-15189927.pdf?src-supplier=Verical; ComponentLink1Description: https://datasheet.ciiva.com/15189/getdatasheetpartid-330251-15189927.pdf?src-supplier=Verical; Component Kind: Standard; Case Code (Metric): 2012; Case Code (Imperial): 0805; Case/Package: 0805; Library Name: Hieu_Lib.IntLib
R8 = Res 0805 100k Ohm 1%: Res Thick Film 0805 100K Ohm 1% 1/8W ±100ppm/°C Molded SMD Paper T/R; Manufacturer Part Number: RC0805FR-07100KL; Manufacturer: Yageo; Voltage Rating (DC): 150V; Resistance: 100kR; Power Rating: 125mW; Width: 0.049inch; Voltage Rating: 150V; Tolerance: 1%; Termination: SMD/SMT; Temperature Coefficient: 100ppm/°C; Technology: THICK FILM; Supplier Part Number 1: 311-100KCRCT-ND; Supplier 1: Digi-Key; Stock 1: 537111; Size Code: 0805; Series: RC; RoHS Compliant: Yes; RoHS: Yes; Resistor Type: Thick Film; Resistance Tolerance: 1%; REACH SVHC: Unknown; Pricing 1: 1 = 0.10000, 10 = 0.04200, 100 = 0.01690, 1000 = 0.00759, 2500 = 0.00659 (USD); Packaging: Tape and Reel; Package Quantity: 1; Number of Terminations: 2; Number of Pins: 2; Min Operating Temperature: -55°C; Military Standard: Not; Max Power Dissipation: 125mW; Max Operating Temperature: 155°C; Length: 2mm; Lead Pitch: 0.4mm; Lead Free: Lead Free; Height: 0.024inch; Features: Moisture Resistant; Depth: 1.25mm; Construction: Rectangular; ComponentLink11URL: https://datasheet.ciiva.com/16747/rc0805-16747226.pdf?src-supplier=Future+Electronics; ComponentLink11Description: https://datasheet.ciiva.com/16747/rc0805-16747226.pdf?src-supplier=Future+Electronics; ComponentLink10URL: https://datasheet.ciiva.com/7941/1697719-7941678.pdf?src-supplier=Element14; ComponentLink10Description: https://datasheet.ciiva.com/7941/1697719-7941678.pdf?src-supplier=Element14; ComponentLink9URL: https://datasheet.ciiva.com/7941/1697719-7941676.pdf?src-supplier=Element14; ComponentLink9Description: https://datasheet.ciiva.com/7941/1697719-7941676.pdf?src-supplier=Element14; ComponentLink8URL: https://datasheet.ciiva.com/12667/rc0805-12667001.pdf?src-supplier=Mouser; ComponentLink8Description: https://datasheet.ciiva.com/12667/rc0805-12667001.pdf?src-supplier=Mouser; ComponentLink7URL: https://datasheet.ciiva.com/2457/pyu-r-int-thick-7-2457017.pdf?src-supplier=Digi-Key; ComponentLink7Description: https://datasheet.ciiva.com/2457/pyu-r-int-thick-7-2457017.pdf?src-supplier=Digi-Key; ComponentLink6URL: https://datasheet.ciiva.com/2457/pyu-r-int-thick-7-2457019.pdf?src-supplier=Digi-Key; ComponentLink6Description: https://datasheet.ciiva.com/2457/pyu-r-int-thick-7-2457019.pdf?src-supplier=Digi-Key; ComponentLink5URL: https://datasheet.ciiva.com/2457/pyu-r-int-thick-7-2457018.pdf?src-supplier=Digi-Key; ComponentLink5Description: https://datasheet.ciiva.com/2457/pyu-r-int-thick-7-2457018.pdf?src-supplier=Digi-Key; ComponentLink4URL: https://datasheet.ciiva.com/22747/444164-22747534.pdf?src-supplier=Element14; ComponentLink4Description: https://datasheet.ciiva.com/22747/444164-22747534.pdf?src-supplier=Element14; ComponentLink3URL: https://datasheet.ciiva.com/7660/38627-7660796.pdf?src-supplier=Element14; ComponentLink3Description: https://datasheet.ciiva.com/7660/38627-7660796.pdf?src-supplier=Element14; ComponentLink2URL: https://datasheet.ciiva.com/7941/1686128-7941677.pdf?src-supplier=Element14; ComponentLink2Description: https://datasheet.ciiva.com/7941/1686128-7941677.pdf?src-supplier=Element14; ComponentLink1URL: https://datasheet.ciiva.com/11808/0900766b8106beab-11808858.pdf?src-supplier=RSComponents; ComponentLink1Description: https://datasheet.ciiva.com/11808/0900766b8106beab-11808858.pdf?src-supplier=RSComponents; Component Kind: Standard; Case Code (Metric): 2012; Case Code (Imperial): 0805; Case/Package: 0805; Library Name: Hieu_Lib.IntLib
R9 = Res 0805 110k Ohm 1%: Res Thick Film 0805 110K Ohm 1% 0.125W(1/8W) ±100ppm/C Pad SMD T/R; Manufacturer Part Number: RC0805FR-07110KL; Manufacturer: Yageo; Working Voltage: 150V; Temperature Coefficient: 100ppm/°C; Resistance: 110kR; Power Rating: 125mW; Width: 0.049inch; Voltage Rating (DC): 150V; Voltage Rating: 150V; Tolerance: 1%; Termination: SMD/SMT; Technology: THICK FILM; Supplier Part Number 1: 311-110KCRCT-ND; Supplier 1: Digi-Key; Stock 1: 0; Series: RC; RoHS Compliant: Yes; RoHS: Yes; Resistor Type: Thick Film; Packaging: Tape and Reel; Package Quantity: 5000; Number of Terminations: 2; Mount: Surface Mount; Min Operating Temperature: -55°C; Military Standard: Not; Max Power Dissipation: 125mW; Max Operating Temperature: 155°C; Length: 2mm; Lead Free: Lead Free; Height: 0.024inch; Features: Moisture Resistant; Depth: 1.25mm; Construction: Rectangular; ComponentLink1URL: https://datasheet.ciiva.com/11819/0900766b8106beab-11819792.pdf?src-supplier=RSComponents; ComponentLink1Description: https://datasheet.ciiva.com/11819/0900766b8106beab-11819792.pdf?src-supplier=RSComponents; Component Kind: Standard; Case Code (Metric): 2012; Case Code (Imperial): 0805; Case/Package: 0805; Library Name: Hieu_Lib.SchLib
R10 = Res 0805 3.6k Ohm 1%: Res Thick Film 0805 3.6K Ohm 1% 0.125W(1/8W) ±100ppm/C Pad SMD T/R; Manufacturer Part Number: RC0805FR-073K6L; Manufacturer: Yageo; Voltage Rating (DC): 150V; Temperature Coefficient: 100ppm/°C; Resistance: 3.6kR; Power Rating: 125mW; Width: 0.049inch; Voltage Rating: 150V; Tolerance: 1%; Termination: SMD/SMT; Technology: THICK FILM; Supplier Part Number 1: 311-3.60KCRCT-ND; Supplier 1: Digi-Key; Stock 1: 0; Size Code: 0805; Series: RC; RoHS Compliant: Yes; RoHS: Yes; Resistor Type: Thick Film; Packaging: Tape and Reel; Package Quantity: 1; Number of Terminations: 2; Mount: Surface Mount; Min Operating Temperature: -55°C; Military Standard: Not; Max Power Dissipation: 125mW; Max Operating Temperature: 155°C; Length: 2mm; Lead Free: Lead Free; Height: 0.5mm; Features: Moisture Resistant; Depth: 1.25mm; Construction: Rectangular; ComponentLink6URL: https://datasheet.ciiva.com/2509/pyu-r-int-thick-7-2509503.pdf?src-supplier=Digi-Key; ComponentLink6Description: https://datasheet.ciiva.com/2509/pyu-r-int-thick-7-2509503.pdf?src-supplier=Digi-Key; ComponentLink5URL: https://datasheet.ciiva.com/17041/rc0805-17041166.pdf?src-supplier=Future+Electronics; ComponentLink5Description: https://datasheet.ciiva.com/17041/rc0805-17041166.pdf?src-supplier=Future+Electronics; ComponentLink4URL: https://datasheet.ciiva.com/2509/pyu-r-int-thick-7-2509502.pdf?src-supplier=Digi-Key; ComponentLink4Description: https://datasheet.ciiva.com/2509/pyu-r-int-thick-7-2509502.pdf?src-supplier=Digi-Key; ComponentLink3URL: https://datasheet.ciiva.com/2509/pyu-r-int-thick-7-2509501.pdf?src-supplier=Digi-Key; ComponentLink3Description: https://datasheet.ciiva.com/2509/pyu-r-int-thick-7-2509501.pdf?src-supplier=Digi-Key; ComponentLink2URL: https://datasheet.ciiva.com/15189/getdatasheetpartid-327996-15189964.pdf?src-supplier=Verical; ComponentLink2Description: https://datasheet.ciiva.com/15189/getdatasheetpartid-327996-15189964.pdf?src-supplier=Verical; ComponentLink1URL: https://datasheet.ciiva.com/11819/0900766b8106beab-11819852.pdf?src-supplier=RSComponents; ComponentLink1Description: https://datasheet.ciiva.com/11819/0900766b8106beab-11819852.pdf?src-supplier=RSComponents; Component Kind: Standard; Case Code (Metric): 2012; Case Code (Imperial): 0805; Case/Package: 0805; Library Name: Hieu_Lib.SchLib
R11 R12 = Res 0805 4.7k Ohm 1%: General Purpose Chip Resistor Thick Film 0805 4.7kOhm 1% Paper T/R; Manufacturer Part Number: RC0805FR-074K7L; Manufacturer: Yageo; Voltage Rating (DC): 150V; Temperature Coefficient: 100ppm/°C; Resistance: 4.7kR; Power Rating: 125mW; Width: 0.049inch; Voltage Rating: 150V; Tolerance: 1%; Termination: SMD/SMT; Technology: THICK FILM; Supplier Part Number 1: 311-4.70KCRCT-ND; Supplier 1: Digi-Key; Stock 1: 159494; Size Code: 0805; Series: RC; RoHS Compliant: Yes; RoHS: Yes; Resistor Type: Thick Film; Resistance Tolerance: 1%; REACH SVHC: No SVHC; Pricing 1: 1 = 0.10000, 10 = 0.04200, 100 = 0.01690, 1000 = 0.00759, 2500 = 0.00659 (USD); Packaging: Tape and Reel; Package Quantity: 5000; Number of Terminations: 2; Number of Pins: 2; Min Operating Temperature: -55°C; Military Standard: Not; Max Power Dissipation: 125mW; Max Operating Temperature: 155°C; Length: 0.079inch; Lead Free: Lead Free; Height: 0.024inch; Features: Moisture Resistant; Depth: 1.25mm; Construction: Rectangular; ComponentLink6URL: https://datasheet.ciiva.com/46447/444164-46447583.pdf?src-supplier=Element14; ComponentLink6Description: https://datasheet.ciiva.com/46447/444164-46447583.pdf?src-supplier=Element14; ComponentLink5URL: https://datasheet.ciiva.com/6547/pyu-r-int-thick-7-6547263.pdf?src-supplier=Digi-Key; ComponentLink5Description: https://datasheet.ciiva.com/6547/pyu-r-int-thick-7-6547263.pdf?src-supplier=Digi-Key; ComponentLink4URL: https://datasheet.ciiva.com/7941/444164-7941806.pdf?src-supplier=Element14; ComponentLink4Description: https://datasheet.ciiva.com/7941/444164-7941806.pdf?src-supplier=Element14; ComponentLink3URL: https://datasheet.ciiva.com/7941/1686128-7941805.pdf?src-supplier=Element14; ComponentLink3Description: https://datasheet.ciiva.com/7941/1686128-7941805.pdf?src-supplier=Element14; ComponentLink2URL: https://datasheet.ciiva.com/7941/444164-7941804.pdf?src-supplier=Element14; ComponentLink2Description: https://datasheet.ciiva.com/7941/444164-7941804.pdf?src-supplier=Element14; ComponentLink1URL: https://datasheet.ciiva.com/11808/0900766b8106beab-11808155.pdf?src-supplier=RSComponents; ComponentLink1Description: https://datasheet.ciiva.com/11808/0900766b8106beab-11808155.pdf?src-supplier=RSComponents; Component Kind: Standard; Case Code (Metric): 2012; Case Code (Imperial): 0805; Case/Package: 0805; Library Name: Hieu_Lib.SchLib
U1 = LM22676MRX-ADJ/NOPB: Conv DC-DC 4.5V to 42V Step Down Single-Out 1.285V to 37V 3A 8-Pin HSOP EP T/R; Manufacturer: TI National Semiconductor; Synchronous Rectifier: No; Switching Frequency: 500kHz; Quiescent Current: 3.4mA; Output Type: Adjustable; Output Configuration: Positive; Number of Outputs: 1; Efficiency: 90%; Supplier Part Number 1: C26495; Supplier 1: LCSC; Stock 1: 0; Series: SIMPLE SWITCHER®; RoHS Compliant: Yes; RoHS: Yes; Radiation Hardening: No; Packaging: Tape and Reel; Package Quantity: 2500; Output Voltage: 1.285V; Output Current: 3A; Operating Supply Voltage: 42V; Number of Pins: 8; Mount: Surface Mount; Min Supply Voltage: 4.5V; Min Output Voltage: 1.285V; Min Operating Temperature: -40°C; Min Input Voltage: 4.5V; Max Output Voltage: 37V; Max Output Current: 3A; Max Operating Temperature: 125°C; Max Input Voltage: 42V; Manufacturer Part Number: LM22676MRX-ADJ/NOPB; Lead Free: Lead Free; Contact Plating: Tin; ComponentLink1URL: https://datasheet.ciiva.com/6604/lm22676-6604507.pdf?src-supplier=Digi-Key; ComponentLink1Description: https://datasheet.ciiva.com/6604/lm22676-6604507.pdf?src-supplier=Digi-Key; Component Kind: Standard; Library Name: Hieu_Lib.IntLib
U2 U3 = Mosfet N (DMTH8003SPS-13): Enhancement Mode MOSFET N-Channel 80V 100A 3.9mOhm 8-Pin PowerDI T/R; Manufacturer: Diodes; Rds On Max: 3.9mR; Max Power Dissipation: 2.9W; Input Capacitance: 8.952nF; Supplier Part Number 1: 621-DMTH8003SPS-13; Supplier 1: Mouser; Stock 1: 2130; RoHS Compliant: Yes; RoHS: Yes; Pricing 1: 1 = 1.99000, 10 = 1.79000, 25 = 1.69000, 50 = 1.69000, 100 = 1.44000, 500 = 1.19000, 1000 = 0.97900, 2500 = 0.88900, 5000 = 0.87700, 10000 = 0.87700 (USD); Packaging: Tape and Reel; Number of Pins: 8; Mount: Surface Mount; Min Operating Temperature: -55°C; Max Operating Temperature: 175°C; Manufacturer Part Number: DMTH8003SPS-13; Drain to Source Voltage (Vdss): 80V; Continuous Drain Current (ID): 100A; Component Kind: Standard; Library Name: Hieu_Lib.IntLib
U4 = LTC4364IMS-2#TRPBF: IC SURGE STOPPER W/DIODE 16MSOP; Manufacturer: Analog Devices / Linear Technology; Working Voltage: 80V; Number of Circuits: 1; Supplier Part Number 1: LTC4364IMS-2#TRPBFCT-ND; Supplier 1: Digi-Key; Stock 1: 5197; RoHS Compliant: Yes; RoHS: Yes; Pricing 1: 1 = 7.72000, 10 = 6.97400, 25 = 6.64920, 100 = 5.77360, 250 = 5.51412, 500 = 5.04900 (USD); Packaging: Tape and Reel; Package Quantity: 2500; Number of Pins: 16; Manufacturer Part Number: LTC4364IMS-2#TRPBF; ComponentLink3URL: http://www.linear.com/docs/41815?src-supplier=Digi-Key; ComponentLink3Description: http://www.linear.com/docs/41815?src-supplier=Digi-Key; ComponentLink2URL: http://www.linear.com/docs/44087?src-supplier=Digi-Key; ComponentLink2Description: http://www.linear.com/docs/44087?src-supplier=Digi-Key; ComponentLink1URL: http://components.arrow.com/part/dataview/1762_06083831?src-supplier=Arrow+Europe; ComponentLink1Description: http://components.arrow.com/part/dataview/1762_06083831?src-supplier=Arrow+Europe; Component Kind: Standard; Case/Package: TFSOP; Library Name: Hieu_Lib.SchLib
U5 = URB2405LD-30WHR3: Converter Isolated 5V/6A; Manufacturer: MORNSUN; Supplier Part Number 1: URB2405LD-30WHR3; Supplier 1: MORNSUN; Manufacturer Part Number: URB2405LD-30WHR3; Component Kind: Standard; Library Name: Hieu_Lib.IntLib
